# Altium schematic sheet TimiBot.SchDoc
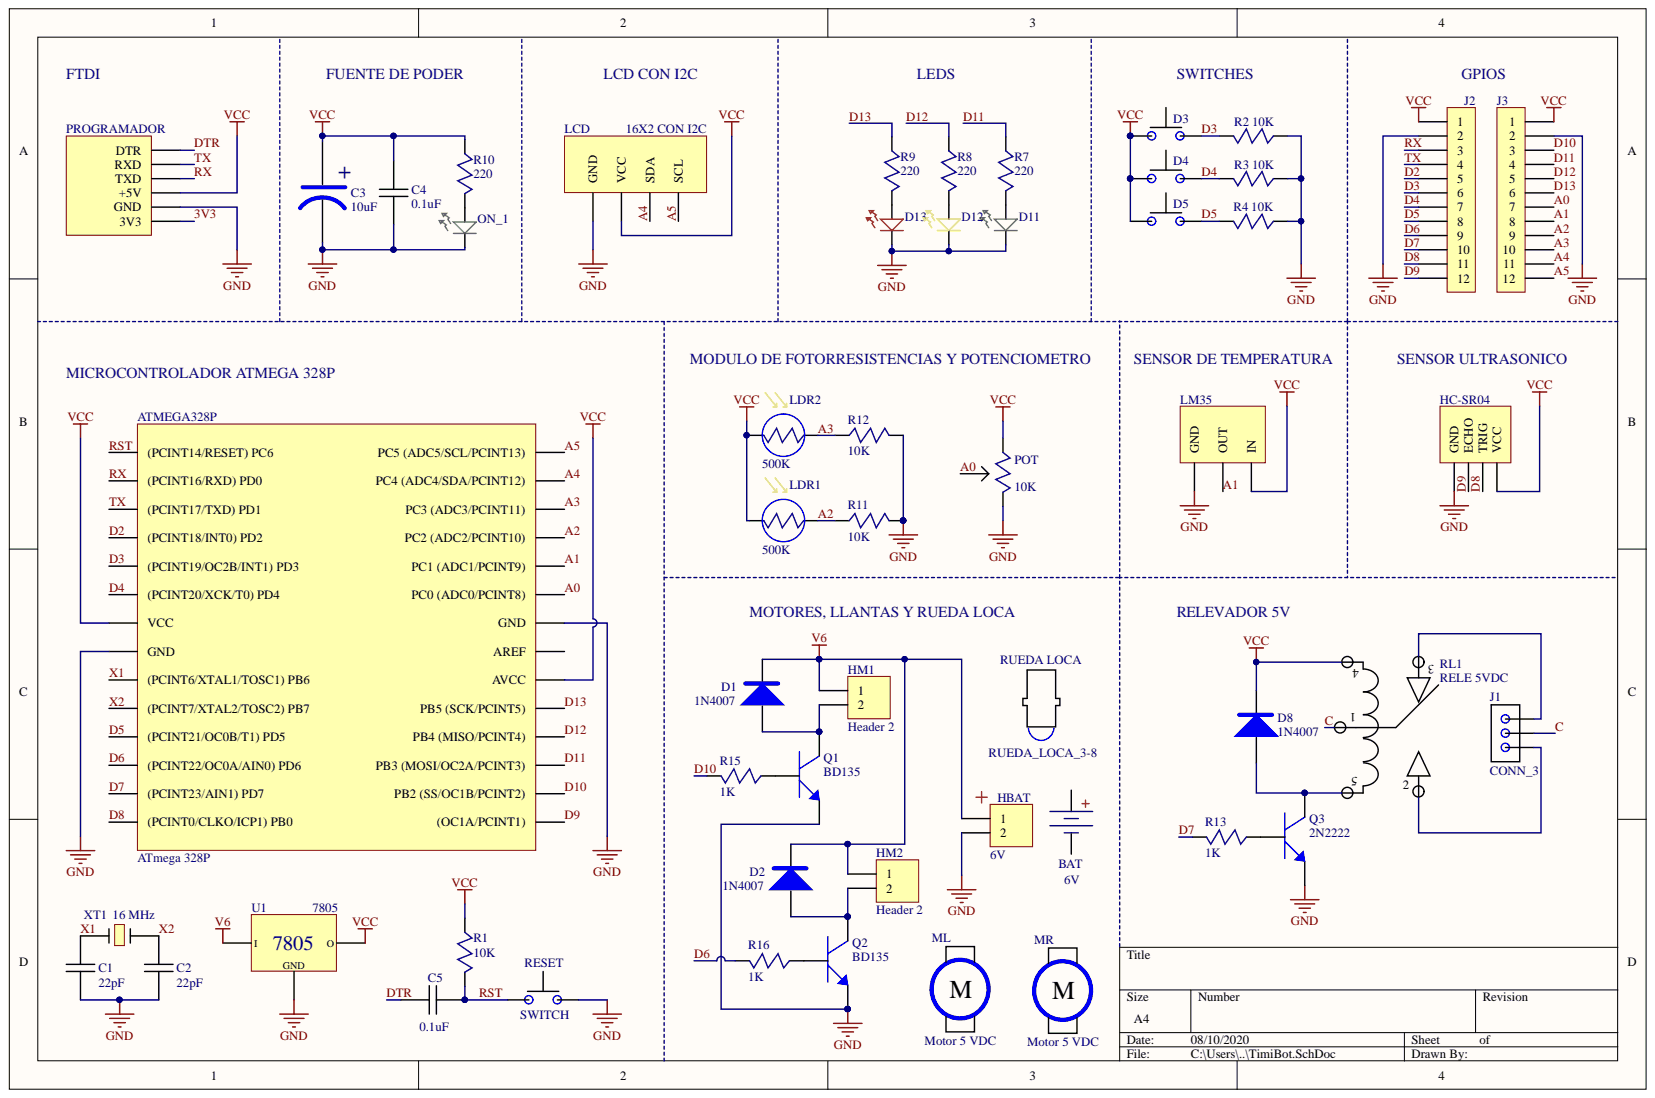
[diagram p0: TimiBot.SchDoc]

## Parts
ATMEGA328P  ATmega 328P  [Atmega_328P]
BAT  6V  [BATTERY_HOLDER]
C1  22pF  [CAPACITER_CERAMIC]
C2  22pF  [CAPACITER_CERAMIC]
C3  10uF  [CAP_ER_D5_X_H11-5_10uF_50V]
C4  0.1uF  [CAPACITER_CERAMIC]
C5  0.1uF  [CAPACITER_CERAMIC]
D1  1N4007  [DIODE_1N4007]
D2  1N4007  [DIODE_1N4007]
D3  (no value)  [SWITCH]
D4  (no value)  [SWITCH]
D5  (no value)  [SWITCH]
D8  1N4007  [DIODE_1N4007]
D11  (no value)  [LED_GREEN]
D12  (no value)  [LED_YELLOW]
D13  (no value)  [LED_RED]
HBAT  6V  [HDR1X2]
HC-SR04  (no value)  [SR04]
HM1  Header 2  [HDR1X2]
HM2  Header 2  [HDR1X2]
J1  CONN_3  [CONN_3_P5MM]
J2  (no value)  [FEMALE HEADER 2.54 MM 12 PIN]
J3  (no value)  [FEMALE HEADER 2.54 MM 12 PIN]
LCD  16X2 CON I2C  [LCD_I2C_SCREW_3X20_MM]
LDR1  500K  [LD_R]
LDR2  500K  [LD_R]
LM35  (no value)  [LM35]
ML  Motor 5 VDC  [Motorreductor_L]
MR  Motor 5 VDC  [Motorreductor_R]
ON_1  (no value)  [LED_GREEN]
POT  10K  [POTENCIOMETRO]
PROGRAMADOR  (no value)  [FTDI]
Q1  BD135  [BD135]
Q2  BD135  [BD135]
Q3  2N2222  [2N2222]
R1  10K  [RES_10K_OHMS_0.25W_0.5W]
R2  10K  [RES_220_OHMS_0.25W_0.5W]
R3  10K  [RES_220_OHMS_0.25W_0.5W]
R4  10K  [RES_220_OHMS_0.25W_0.5W]
R7  220  [RES_220_OHMS_0.25W_0.5W]
R8  220  [RES_220_OHMS_0.25W_0.5W]
R9  220  [RES_220_OHMS_0.25W_0.5W]
R10  220  [RES_220_OHMS_0.25W_0.5W]
R11  10K  [RES_10K_OHMS_0.25W_0.5W]
R12  10K  [RES_10K_OHMS_0.25W_0.5W]
R13  1K  [RES_1K_OHMS_0.25W_0.5W]
R15  1K  [RES_1K_OHMS_0.25W_0.5W]
R16  1K  [RES_1K_OHMS_0.25W_0.5W]
RESET  SWITCH  [SWITCH]
RL1  RELE 5VDC  [RELEVADOR_5V]
RUEDA_LOCA  RUEDA_LOCA_3-8  [RUEDA_LOCA_3-8]
U1  7805  [REG_7805]
XT1  16 MHz  [CRYSTAL_16MHZ]

## Nets
GND: ATMEGA328P.8(GND) ATMEGA328P.22(GND) C1.1 C2.1 C3.2 C4.1 D11.2 D12.2 D13.2 HBAT.2 HC-SR04.4(GND) J2.2 J3.2 LCD.1(GND) LM35.3 ON_1.2 POT.3 PROGRAMADOR.5(GND) Q1.1 Q2.1 Q3.1 R2.2 R3.2 R4.2 R11.1 R12.1 RESET.2 U1.2
V6: D1.2 D2.2 HBAT.1 HM1.1 HM2.1 U1.1
VCC: ATMEGA328P.7(VCC) ATMEGA328P.20(AVCC) C3.1 C4.2 D3.1 D4.1 D5.1 D8.2 HC-SR04.1(VCC) J2.1 J3.1 LCD.2(VCC) LDR1.1 LDR2.1 LM35.1 POT.1 PROGRAMADOR.4(+5V) R1.2 R10.2 RL1.4 U1.3
3V3: PROGRAMADOR.6(3V3)
A0: ATMEGA328P.23(PC0_(ADC0/PCINT8)) J3.7 POT.2
A1: ATMEGA328P.24(PC1_(ADC1/PCINT9)) J3.8 LM35.2
A2: ATMEGA328P.25(PC2_(ADC2/PCINT10)) J3.9 LDR1.2 R11.2
A3: ATMEGA328P.26(PC3_(ADC3/PCINT11)) J3.10 LDR2.2 R12.2
A4: ATMEGA328P.27(PC4_(ADC4/SDA/PCINT12)) J3.11 LCD.3(SDA)
A5: ATMEGA328P.28(PC5_(ADC5/SCL/PCINT13)) J3.12 LCD.4(SCL)
C: J1.2 RL1.1
D2: ATMEGA328P.4((PCINT18/INT0)_PD2) J2.5
D3: ATMEGA328P.5((PCINT19/OC2B/INT1)_PD3) D3.2 J2.6 R2.1
D4: ATMEGA328P.6((PCINT20/XCK/T0)_PD4) D4.2 J2.7 R3.1
D5: ATMEGA328P.11((PCINT21/OC0B/T1)_PD5) D5.2 J2.8 R4.1
D6: ATMEGA328P.12((PCINT22/OC0A/AIN0)_PD6) J2.9 R16.1
D7: ATMEGA328P.13((PCINT23/AIN1)_PD7) J2.10 R13.1
D8: ATMEGA328P.14((PCINT0/CLKO/ICP1)_PB0) HC-SR04.2(TRIG) J2.11
D9: ATMEGA328P.15((OC1A/PCINT1)) HC-SR04.3(ECHO) J2.12
D10: ATMEGA328P.16(PB2_(SS/OC1B/PCINT2)) J3.3 R15.1
D11: ATMEGA328P.17(PB3_(MOSI/OC2A/PCINT3)) J3.4 R7.2
D12: ATMEGA328P.18(PB4_(MISO/PCINT4)) J3.5 R8.2
D13: ATMEGA328P.19(PB5_(SCK/PCINT5)) J3.6 R9.2
DTR: C5.1 PROGRAMADOR.1(DTR)
RST: ATMEGA328P.1((PCINT14/RESET)_PC6) C5.2 R1.1 RESET.1
RX: ATMEGA328P.2((PCINT16/RXD)_PD0) J2.3 PROGRAMADOR.3(TXD)
TX: ATMEGA328P.3((PCINT17/TXD)_PD1) J2.4 PROGRAMADOR.2(RXD)
X1: ATMEGA328P.9((PCINT6/XTAL1/TOSC1)_PB6) C1.2 XT1.1
X2: ATMEGA328P.10((PCINT7/XTAL2/TOSC2)_PB7) C2.2 XT1.2
NetD1_1: D1.1 HM1.2 Q1.2
NetD2_1: D2.1 HM2.2 Q2.2
NetD8_1: D8.1 Q3.3 RL1.5
NetD11_1: D11.1 R7.1
NetD12_1: D12.1 R8.1
NetD13_1: D13.1 R9.1
NetJ1_1: J1.1 RL1.3
NetJ1_3: J1.3 RL1.2
NetON_1_1: ON_1.1 R10.1
NetQ1_3: Q1.3 R15.2
NetQ2_3: Q2.3 R16.2
NetQ3_2: Q3.2 R13.2

Not connected: ATMEGA328P 21(AREF); BAT + -

## Library data of the parts
ATMEGA328P = Atmega_328P: Component Kind: Standard; Library Name: Atmega_328P.SchLib
BAT = BATTERY_HOLDER: Component Kind: Standard; Library Name: BATTERY_HOLDER.SchLib
C1 C2 C4 C5 = CAPACITER_CERAMIC: Component Kind: Standard; Library Name: CAPACITER_CERAMIC.SchLib
C3 = CAP_ER_D5_X_H11-5_10uF_50V: 10uF 50V; Component Kind: Standard; Library Name: CAP_ER_D5_H11-5_10uF_50V.SchLib
D1 D2 D8 = DIODE_1N4007: Component Kind: Standard; Library Name: DIODE_1N4007.SchLib
D3-D5 = SWITCH: Component Kind: Standard; Library Name: SWITCH.SchLib
D11 ON_1 = LED_GREEN: 5mm; Component Kind: Standard; Library Name: LED_GREEN.SchLib
D12 = LED_YELLOW: 5mm; Component Kind: Standard; Library Name: LED_YELLOW.SchLib
D13 = LED_RED: 5mm; Component Kind: Standard; Library Name: LED_RED.SchLib
HBAT HM1 HM2 = Header 2: Header, 2-Pin; Component Kind: Standard; LatestRevisionDate: 17-Jul-2002; LatestRevisionNote: Re-released for DXP Platform.; Library Name: Miscellaneous Connectors.IntLib; Signal Integrity: Connector; Publisher: Altium Limited
HC-SR04 = SR04: Component Kind: Standard; Library Name: SR04.SchLib
J1 = CONN_3_P5MM_13.5H: Component Kind: Standard; Library Name: CONN_3_P5MM_13.5H.SchLib
J2 J3 = FEMALE HEADER 2.54 MM 12 PIN: Component Kind: Standard; Library Name: FEMALE HEADER 2.54 MM 12 PIN.SchLib
LCD = LCD_I2C_SCREW_3X20_MM: Component Kind: Standard; Library Name: LCD_I2C_SCREW_3X20_MM.SchLib
LDR1 LDR2 = LD_R: Component Kind: Standard; Library Name: LD_R.SchLib
LM35 = LM35: Component Kind: Standard; Library Name: LM35.SchLib
ML = MOTORREDUCTOR_L: Component Kind: Standard; Library Name: MOTORREDUCTOR_L.SchLib
MR = MOTORREDUCTOR_R: Component Kind: Standard; Library Name: MOTORREDUCTOR_R.SchLib
POT = POTENCIOMETRO: Component Kind: Standard; Library Name: POTENCIOMETRO.SchLib
PROGRAMADOR = FTDI: Component Kind: Standard; Library Name: FTDI.SchLib
R1 R11 R12 = RES_10K_OHMS_0.25W_0.5W: Component Kind: Standard; Library Name: RES_10K_OHMS_0.25W_0.5W.SchLib
R2-R4 R7-R10 = RES_220_OHMS_0.25W_0.5W: Component Kind: Standard; Library Name: RES_220_OHMS_0.25W_0.5W.SchLib
R13 R15 R16 = RES_1K_OHMS_0.25W_0.5W: Component Kind: Standard; Library Name: RES_1K_OHMS_0.25W_0.5W.SchLib
RL1 = RELEVADOR_5V: Component Kind: Standard; Library Name: RELEVADOR_5V.SchLib
U1 = REG_7805: Component Kind: Standard; Library Name: REG_7805.SchLib
XT1 = CRYSTAL_16MHZ: Component Kind: Standard; Library Name: CRYSTAL_16MHZ.SchLib
Q1 Q2 = BD135: Component Kind: Standard; Library Name: BD135.SchLib
Q3 = 2N2222: Component Kind: Standard; Library Name: 2N2222.SchLib
RESET = SWITCH: Component Kind: Standard; Library Name: SWITCH.SchLib
RUEDA_LOCA = RUEDA_LOCA_3-8: Component Kind: Standard; Library Name: RUEDA_LOCA_3-8.SchLib
